# Altium schematic sheet MOTORES Bluetooth.SchDoc
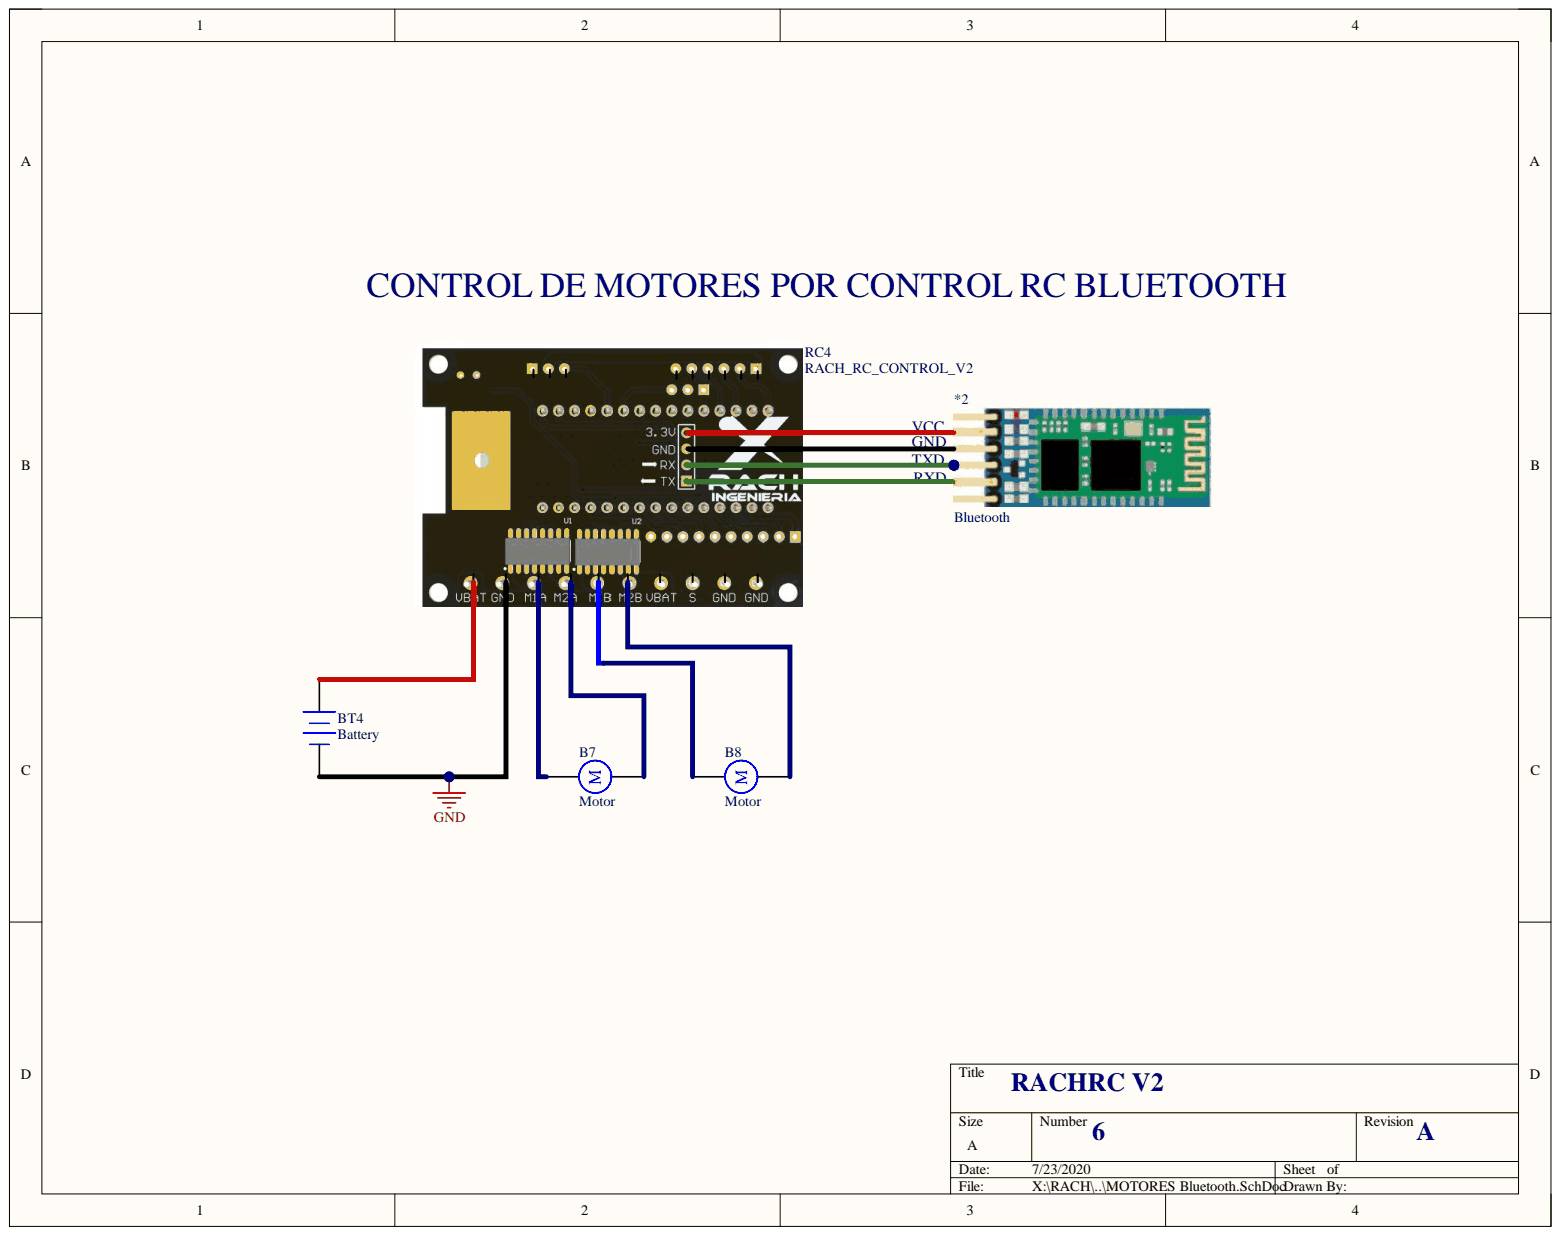
[diagram p0: MOTORES Bluetooth.SchDoc]

## Parts
*2  Bluetooth
B7  Motor  [RB5-10.5]
B8  Motor  [RB5-10.5]
BT4  Battery  [BAT-2]
RC4  RACH_RC_CONTROL_V2

## Nets
GND: BT4.2 RC4.19
NetB7_1: B7.1 RC4.17
NetB7_2: B7.2 RC4.18
NetB8_1: B8.1 RC4.15
NetB8_2: B8.2 RC4.16
NetBT4_1: BT4.1 RC4.20

Not connected: *2 5 6 7 8; RC4 2 3 4 5 6 7 8 9 10 11 12 13 14

## Library data of the parts
B7 B8 = Motor: Motor, General Kind; Component Kind: Standard; LatestRevisionDate: 17-Jul-2002; LatestRevisionNote: Re-released for DXP Platform.; Library Name: Miscellaneous Devices.IntLib; PackageDescription: Capacitor (Radial); 2 Leads; PackageReference: RB5-10.5; Published: 24-Mar-1999; Publisher: Altium Limited
BT4 = Battery: Multicell Battery; Component Kind: Standard; LatestRevisionDate: 17-Jul-2002; LatestRevisionNote: Re-released for DXP Platform.; Library Name: Miscellaneous Devices.IntLib; PackageDescription: Battery; 2 Leads; PackageReference: BAT-2; Published: 24-Mar-1999; Publisher: Altium Limited
RC4 = RACH_RC_CONTROL_V2_V: Component Kind: Standard; Library Name: Componentes.SchLib
*2 = Bluetooth: Component Kind: Standard; Library Name: Componentes.SchLib
